# Altium schematic sheet servo.SchDoc(servo)
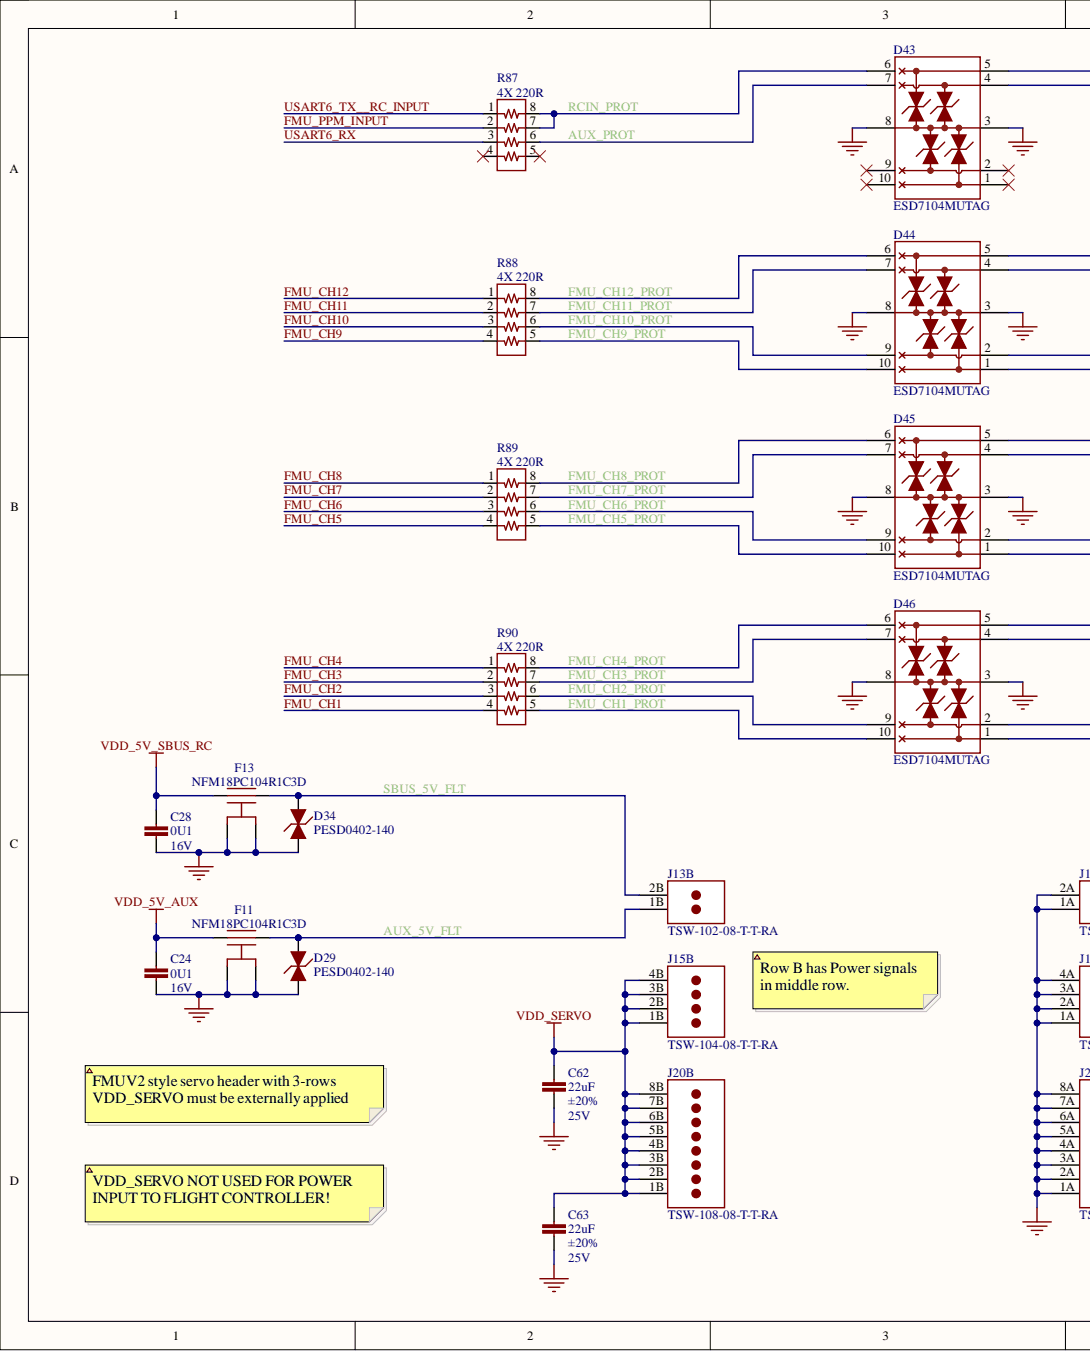
[diagram p0: servo.SchDoc, left]
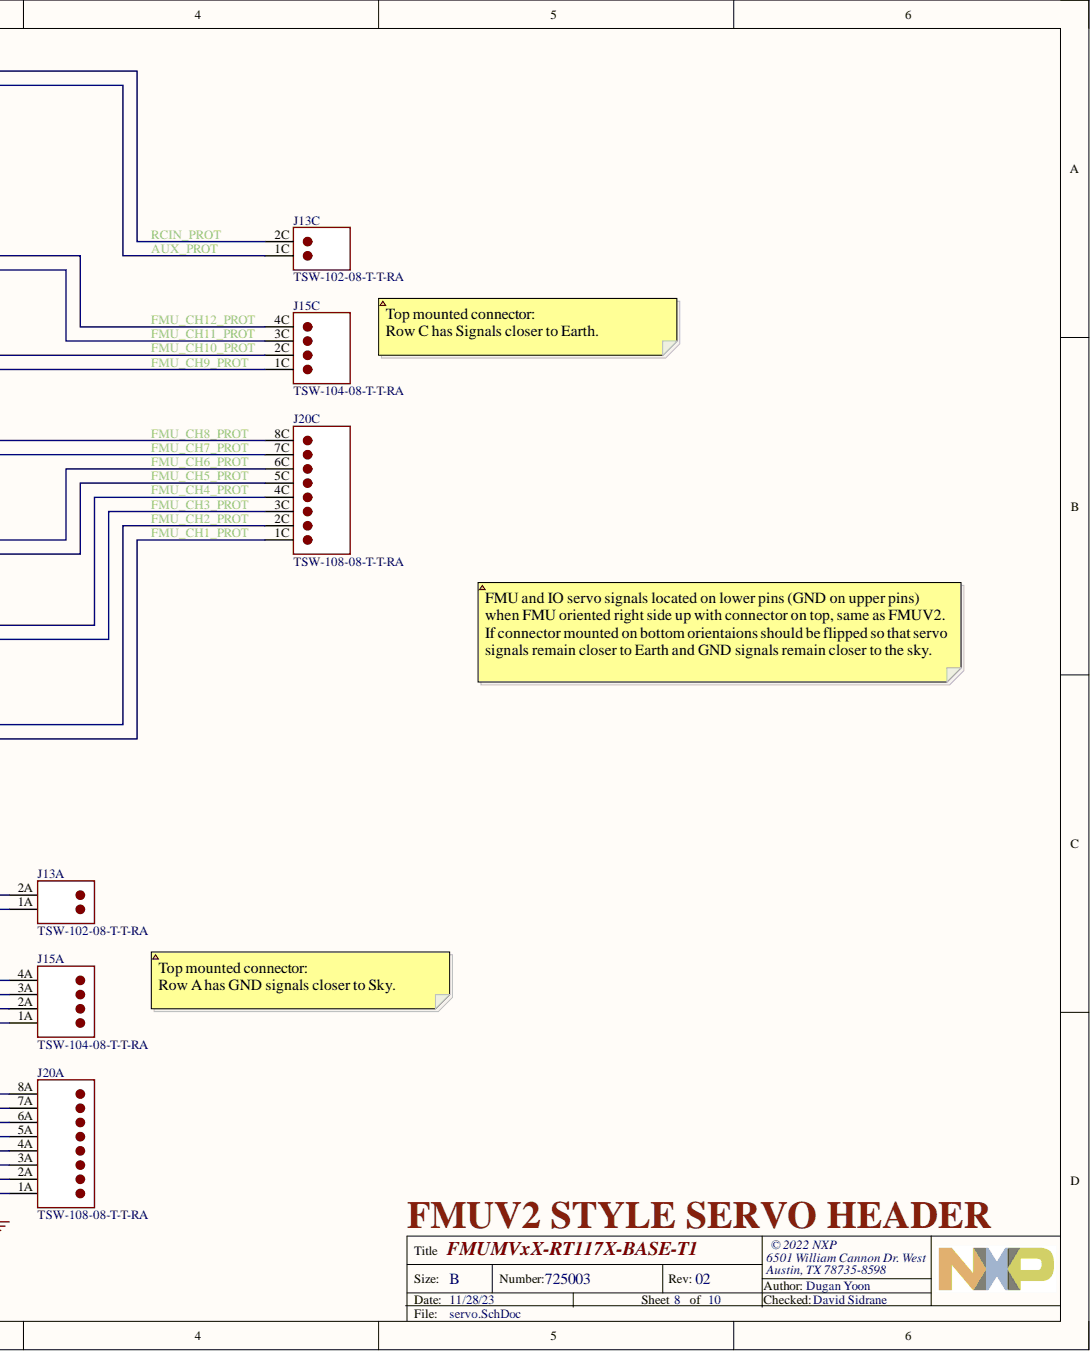
[diagram p1: servo.SchDoc, right]

## Parts
C24  value 0U1  Voltage - Rated: 16V  [CAPC100X50X56L25N]  (hidden comment: CAP CER 0.1UF 10% 16V X7R 0402)
C28  value 0U1  Voltage - Rated: 16V  [CAPC100X50X56L25N]  (hidden comment: CAP CER 0.1UF 10% 16V X7R 0402)
C62  value 22uF  Tolerance: ±20%  Voltage - Rated: 25V  [CAPC200X125X70L40N]  (hidden comment: CAP CER 22UF 20% 25V X5R 0805)
C63  value 22uF  Tolerance: ±20%  Voltage - Rated: 25V  [CAPC200X125X70L40N]  (hidden comment: CAP CER 22UF 20% 25V X5R 0805)
D29  Manufacturer Part Number: PESD0402-140  [DIONC100X50X43L20N]  (hidden comment: DIODE TVS BIDIRECTIONAL 14VWM 40VC 0402)
D34  Manufacturer Part Number: PESD0402-140  [DIONC100X50X43L20N]  (hidden comment: DIODE TVS BIDIRECTIONAL 14VWM 40VC 0402)
D43  Manufacturer Part Number: ESD7104MUTAG  [UDFN10P50_250X100X55L35X20N]  (hidden comment: DIODE TVS BIDIRECTIONAL 5V 10V 10UDFN)
D44  Manufacturer Part Number: ESD7104MUTAG  [UDFN10P50_250X100X55L35X20N]  (hidden comment: DIODE TVS BIDIRECTIONAL 5V 10V 10UDFN)
D45  Manufacturer Part Number: ESD7104MUTAG  [UDFN10P50_250X100X55L35X20N]  (hidden comment: DIODE TVS BIDIRECTIONAL 5V 10V 10UDFN)
D46  Manufacturer Part Number: ESD7104MUTAG  [UDFN10P50_250X100X55L35X20N]  (hidden comment: DIODE TVS BIDIRECTIONAL 5V 10V 10UDFN)
F11  Manufacturer Part Number: NFM18PC104R1C3D  [NFM18PC104R1C3_0603_NO_VIA]  (hidden comment: FILTER CAP FEEDTHRU 0.1UF 20% 16V 0603)
F13  Manufacturer Part Number: NFM18PC104R1C3D  [NFM18PC104R1C3_0603_NO_VIA]  (hidden comment: FILTER CAP FEEDTHRU 0.1UF 20% 16V 0603)
J13  Manufacturer Part Number: TSW-102-08-T-T-RA  [3X2RA_ABC]  (hidden comment: CONN HDR 6POS 2.54MM RA TH)  (parts A,B,C)
J15  Manufacturer Part Number: TSW-104-08-T-T-RA  [3X4RA_ABC]  (hidden comment: CONN HDR 12POS 2.54MM RA TH)  (parts A,B,C)
J20  Manufacturer Part Number: TSW-108-08-T-T-RA  [3X8RA_ABC]  (hidden comment: CONN HDR 24POS 2.54MM RA TH)  (parts A,B,C)
R87  value 4X 220R  [RESCAF8P80_320X160X61L30X65N]  (hidden comment: RES ARRAY 4 RES 220 OHM 1206)
R88  value 4X 220R  [RESCAF8P80_320X160X61L30X65N]  (hidden comment: RES ARRAY 4 RES 220 OHM 1206)
R89  value 4X 220R  [RESCAF8P80_320X160X61L30X65N]  (hidden comment: RES ARRAY 4 RES 220 OHM 1206)
R90  value 4X 220R  [RESCAF8P80_320X160X61L30X65N]  (hidden comment: RES ARRAY 4 RES 220 OHM 1206)

## Nets
GND: C24.2 C28.2 C62.2 C63.2 D29.1 D34.1 D43.3 D43.8 D44.3 D44.8 D45.3 D45.8 D46.3 D46.8 F11.2A F11.2B F13.2A F13.2B J13.1A J13.2A J15.1A J15.2A J15.3A J15.4A J20.1A J20.2A J20.3A J20.4A J20.5A J20.6A J20.7A J20.8A
VDD_5V_AUX: C24.1 F11.1
VDD_5V_SBUS_RC: C28.1 F13.1
VDD_SERVO: C62.1 C63.1 J15.1B J15.2B J15.3B J15.4B J20.1B J20.2B J20.3B J20.4B J20.5B J20.6B J20.7B J20.8B
AUX_5V_FLT: D29.2 F11.3 J13.1B
AUX_PROT: D43.4 D43.7 J13.1C R87.6
FMU_CH1: R90.4
FMU_CH1_PROT: D46.1 D46.10 J20.1C R90.5
FMU_CH2: R90.3
FMU_CH2_PROT: D46.2 D46.9 J20.2C R90.6
FMU_CH3: R90.2
FMU_CH3_PROT: D46.4 D46.7 J20.3C R90.7
FMU_CH4: R90.1
FMU_CH4_PROT: D46.5 D46.6 J20.4C R90.8
FMU_CH5: R89.4
FMU_CH5_PROT: D45.1 D45.10 J20.5C R89.5
FMU_CH6: R89.3
FMU_CH6_PROT: D45.2 D45.9 J20.6C R89.6
FMU_CH7: R89.2
FMU_CH7_PROT: D45.4 D45.7 J20.7C R89.7
FMU_CH8: R89.1
FMU_CH8_PROT: D45.5 D45.6 J20.8C R89.8
FMU_CH9: R88.4
FMU_CH9_PROT: D44.1 D44.10 J15.1C R88.5
FMU_CH10: R88.3
FMU_CH10_PROT: D44.2 D44.9 J15.2C R88.6
FMU_CH11: R88.2
FMU_CH11_PROT: D44.4 D44.7 J15.3C R88.7
FMU_CH12: R88.1
FMU_CH12_PROT: D44.5 D44.6 J15.4C R88.8
FMU_PPM_INPUT: R87.2
RCIN_PROT: D43.5 D43.6 J13.2C R87.7 R87.8
SBUS_5V_FLT: D34.2 F13.3 J13.2B
USART6_RX: R87.3
USART6_TX__RC_INPUT: R87.1

Not connected: D43 1 2 9 10; R87 4 5

## Library data of the parts
C24 C28 = CAP_0U1_16V_X7R_0402: Manufacturer: Murata Electronics; Manufacturer Part Number: GCM155R71C104KA55J; Category: Capacitors; Component Kind: Standard; ComponentLink1Description: https://www.digikey.com/product-detail/en/murata-electronics/GCM155R71C104KA55J/490-7998-1-ND/4380283; ComponentLink1URL: https://www.digikey.com/product-detail/en/murata-electronics/GCM155R71C104KA55J/490-7998-1-ND/4380283; Description: CAP CER 0.1UF 10% 16V X7R 0402; Operating Temperature: -55°C ~ 125°C; Packaging: Cut Tape (CT); Part Status: Active; Pricing 1: 1=0.1, 10=0.049, 100=0.022, 500=0.0157, 1000=0.01233, 2500=0.01121, 5000=0.01032 (USD); Stock 1: 6381653; Supplier 1: Digi-Key; Supplier Part Number 1: 490-7998-1-ND; Tolerance: ±10%; Library Name: NscDG_Integrated_Library.SchLib
C62 C63 = CAP_22UF_20%_25V_X5R_0805: Manufacturer: Murata Electronics; Manufacturer Part Number: GRT21BR61E226ME13L; Category: Capacitors; Component Kind: Standard; ComponentLink1Description: https://www.digikey.com/en/products/detail/murata-electronics/GRT21BR61E226ME13L/5416811; ComponentLink1URL: https://www.digikey.com/en/products/detail/murata-electronics/GRT21BR61E226ME13L/5416811; Description: CAP CER 22UF 20% 25V X5R 0805; Operating Temperature: -55°C ~ 125°C; Packaging: Cut Tape (CT); Part Status: Active; Pricing 1: 1=0.58 (USD); Stock 1: 0; Supplier 1: Digi-Key; Supplier Part Number 1: 490-12389-1-ND; Library Name: NscDG_Integrated_Library.SchLib
D29 D34 = DIODE_TVS_0402: Manufacturer: Littelfuse Inc.; Category: Circuit Protection; Component Kind: Standard; ComponentLink1Description: https://www.digikey.com/products/en/circuit-protection/tvs-diodes/144?k=PESD0402-140&k=&pkeyword=PESD0402-140&pv7=2&sf=0&quantity=&ColumnSort=0&page=1&pageSize=25; ComponentLink1URL: https://www.digikey.com/products/en/circuit-protection/tvs-diodes/144?k=PESD0402-140&k=&pkeyword=PESD0402-140&pv7=2&sf=0&quantity=&ColumnSort=0&page=1&pageSize=25; Description: DIODE TVS BIDIRECTIONAL 14VWM 40VC 0402; Operating Temperature: -55°C ~ 125°C (TJ); Packaging: Cut Tape (CT); Part Status: Active; Pricing 1: 10000=0.13154, 30000=0.12096 (USD); Stock 1: 281442; Supplier 1: Digi-Key; Supplier Part Number 1: PESD0402-140CT-ND; Library Name: NscDG_Integrated_Library.SchLib
D43-D46 = DIODE_TVS_4X_10UDFN: Manufacturer: ON Semiconductor; Category: Circuit Protection; Component Kind: Standard; ComponentLink1Description: https://www.digikey.com/product-detail/en/on-semiconductor/ESD7104MUTAG/ESD7104MUTAGOSCT-ND/3487538; ComponentLink1URL: https://www.digikey.com/product-detail/en/on-semiconductor/ESD7104MUTAG/ESD7104MUTAGOSCT-ND/3487538; Description: DIODE TVS BIDIRECTIONAL 5V 10V 10UDFN; Operating Temperature: -55°C ~ 125°C (TJ); Packaging: Cut Tape (CT); Part Status: Active; Pricing 1: 1=0.63, 10=0.541, 100=0.40370, 500=0.31716, 1000=0.24508 (USD); Stock 1: 5750; Supplier 1: Digi-Key; Supplier Part Number 1: ESD7104MUTAGOSCT-ND; Library Name: NscDG_Integrated_Library.SchLib
F11 F13 = FILTER_0.1uF_16V_2A_NO_VIA_0603: Manufacturer: Murata Electronics North America; Category: Filters; Component Kind: Standard; ComponentLink1Description: https://www.digikey.com/products/en/filters/feed-through-capacitors/845?k=NFM18PC104R1C3D&k=&pkeyword=NFM18PC104R1C3D&pv7=2&sf=0&quantity=&ColumnSort=0&page=1&pageSize=25; ComponentLink1URL: https://www.digikey.com/products/en/filters/feed-through-capacitors/845?k=NFM18PC104R1C3D&k=&pkeyword=NFM18PC104R1C3D&pv7=2&sf=0&quantity=&ColumnSort=0&page=1&pageSize=25; Description: FILTER CAP FEEDTHRU 0.1UF 20% 16V 0603; Operating Temperature: -55°C ~ 125°C; Packaging: Cut Tape (CT); Part Status: Active; Pricing 1: 1=0.19, 10=0.173, 25=0.1652, 50=0.1598, 100=0.1544, 250=0.1464, 500=0.12246, 1000=0.09503 (USD); Stock 1: 172860; Supplier 1: Digi-Key; Supplier Part Number 1: 490-6969-1-ND; Tolerance: ±20%; Voltage - Rated: 16V; Library Name: NscDG_Integrated_Library.SchLib
J13 = CONN_HDR_3X2_RA_TH: Manufacturer: Samtec; System: Connector; Category: Connectors, Interconnects; Component Kind: Standard; ComponentLink1Description: https://www.digikey.com/en/products/detail/samtec-inc/TSW-102-08-T-T-RA/6614025; ComponentLink1URL: https://www.digikey.com/en/products/detail/samtec-inc/TSW-102-08-T-T-RA/6614025; Description: CONN HDR 6POS 2.54MM RA TH; Operating Temperature: -55°C ~ 125°C; Packaging: Bulk; Part Status: Active; Pricing 1: 1=0.78 (USD); Stock 1: 468; Supplier 1: Digi-Key; Supplier Part Number 1: TSW-102-08-T-T-RA-ND; Voltage - Rated: -; Library Name: NscDG_Integrated_Library.SchLib
J15 = CONN_HDR_3X4_RA_TH: Manufacturer: Samtec; System: Connector; Category: Connectors, Interconnects; Component Kind: Standard; ComponentLink1Description: https://www.digikey.com/en/products/detail/samtec-inc/TSW-104-08-T-T-RA/6691863; ComponentLink1URL: https://www.digikey.com/en/products/detail/samtec-inc/TSW-104-08-T-T-RA/6691863; Description: CONN HDR 12POS 2.54MM RA TH; Operating Temperature: -55°C ~ 125°C; Packaging: Bulk; Part Status: Active; Pricing 1: 1=1.41 (USD); Stock 1: 497; Supplier 1: Digi-Key; Supplier Part Number 1: SAM13035-ND; Voltage - Rated: -; Library Name: NscDG_Integrated_Library.SchLib
J20 = CONN_HDR_3X8RA_TH: Manufacturer: Samtec; System: Connector; Category: Connectors, Interconnects; Component Kind: Standard; ComponentLink1Description: https://www.digikey.com/product-detail/en/samtec-inc/TSW-108-08-T-T-RA/TSW-108-08-T-T-RA-ND/7869165; ComponentLink1URL: https://www.digikey.com/product-detail/en/samtec-inc/TSW-108-08-T-T-RA/TSW-108-08-T-T-RA-ND/7869165; Description: CONN HDR 24POS 2.54MM RA TH; Operating Temperature: -55°C ~ 125°C; Packaging: Bulk; Part Status: Active; Pricing 1: 1=1.53 (USD); Stock 1: 302; Supplier 1: Digi-Key; Supplier Part Number 1: TSW-108-08-T-T-RA-ND; Voltage - Rated: -; Library Name: NscDG_Integrated_Library.SchLib
R87-R90 = RES_ARRAY_220_5%_1/16W_1206: Manufacturer: Yageo; Manufacturer Part Number: YC164-JR-07220RL; Power: 1/16W; Tolerance: ±5%; Category: Resistors; Component Kind: Standard; ComponentLink1Description: https://www.digikey.com/products/en/resistors/resistor-networks-arrays/50?k=&pkeyword=&pv16=9574&sf=0&FV=fffc000d%2C1c0002%2C1f140000%2C24280001%2Cffe00032%2C40136&quantity=&ColumnSort=0&page=1&pageSize=25; ComponentLink1URL: https://www.digikey.com/products/en/resistors/resistor-networks-arrays/50?k=&pkeyword=&pv16=9574&sf=0&FV=fffc000d%2C1c0002%2C1f140000%2C24280001%2Cffe00032%2C40136&quantity=&ColumnSort=0&page=1&pageSize=25; Description: RES ARRAY 4 RES 220 OHM 1206; Operating Temperature: -55°C ~ 155°C; Packaging: Cut Tape (CT); Part Status: Active; Pricing 1: 1=0.1, 10=0.014, 100=0.0056, 1000=0.00253, 2500=0.0022, 5000=0.00181 (USD); Stock 1: 17927; Supplier 1: Digi-Key; Supplier Part Number 1: YC164J-220CT-ND; Library Name: NscDG_Integrated_Library.SchLib
